# Altium schematic sheet AD9854优化版.SchDoc
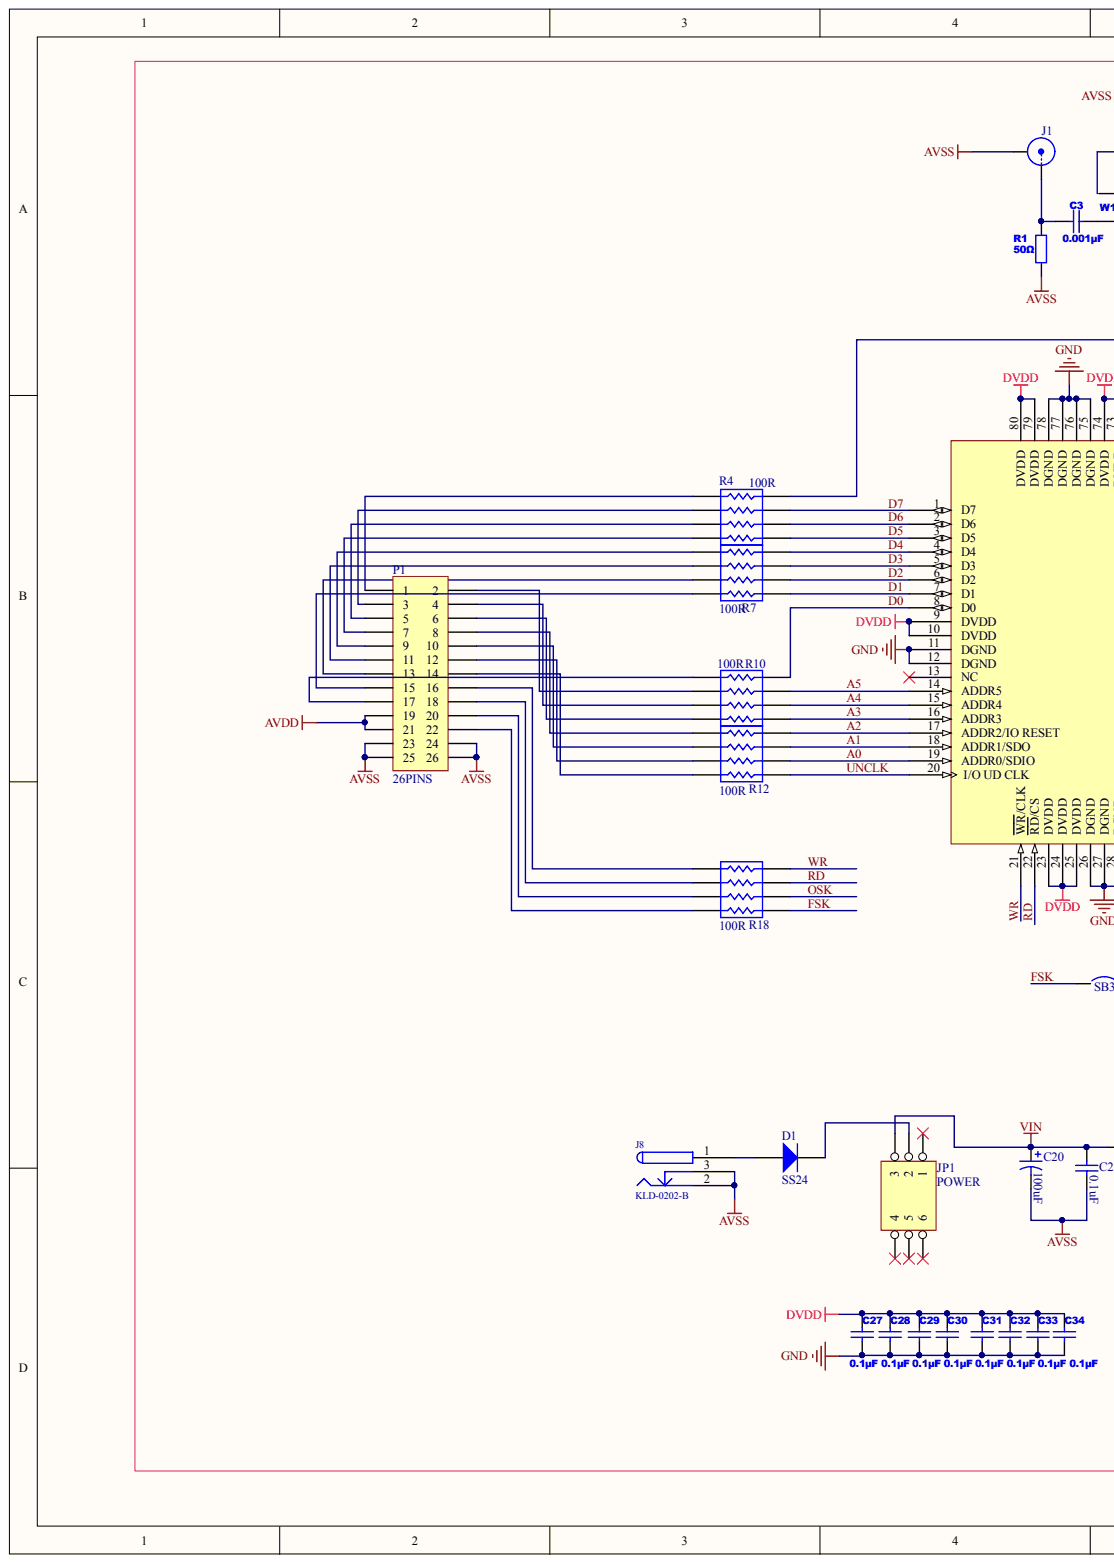
[diagram p0: AD9854优化版.SchDoc, left]
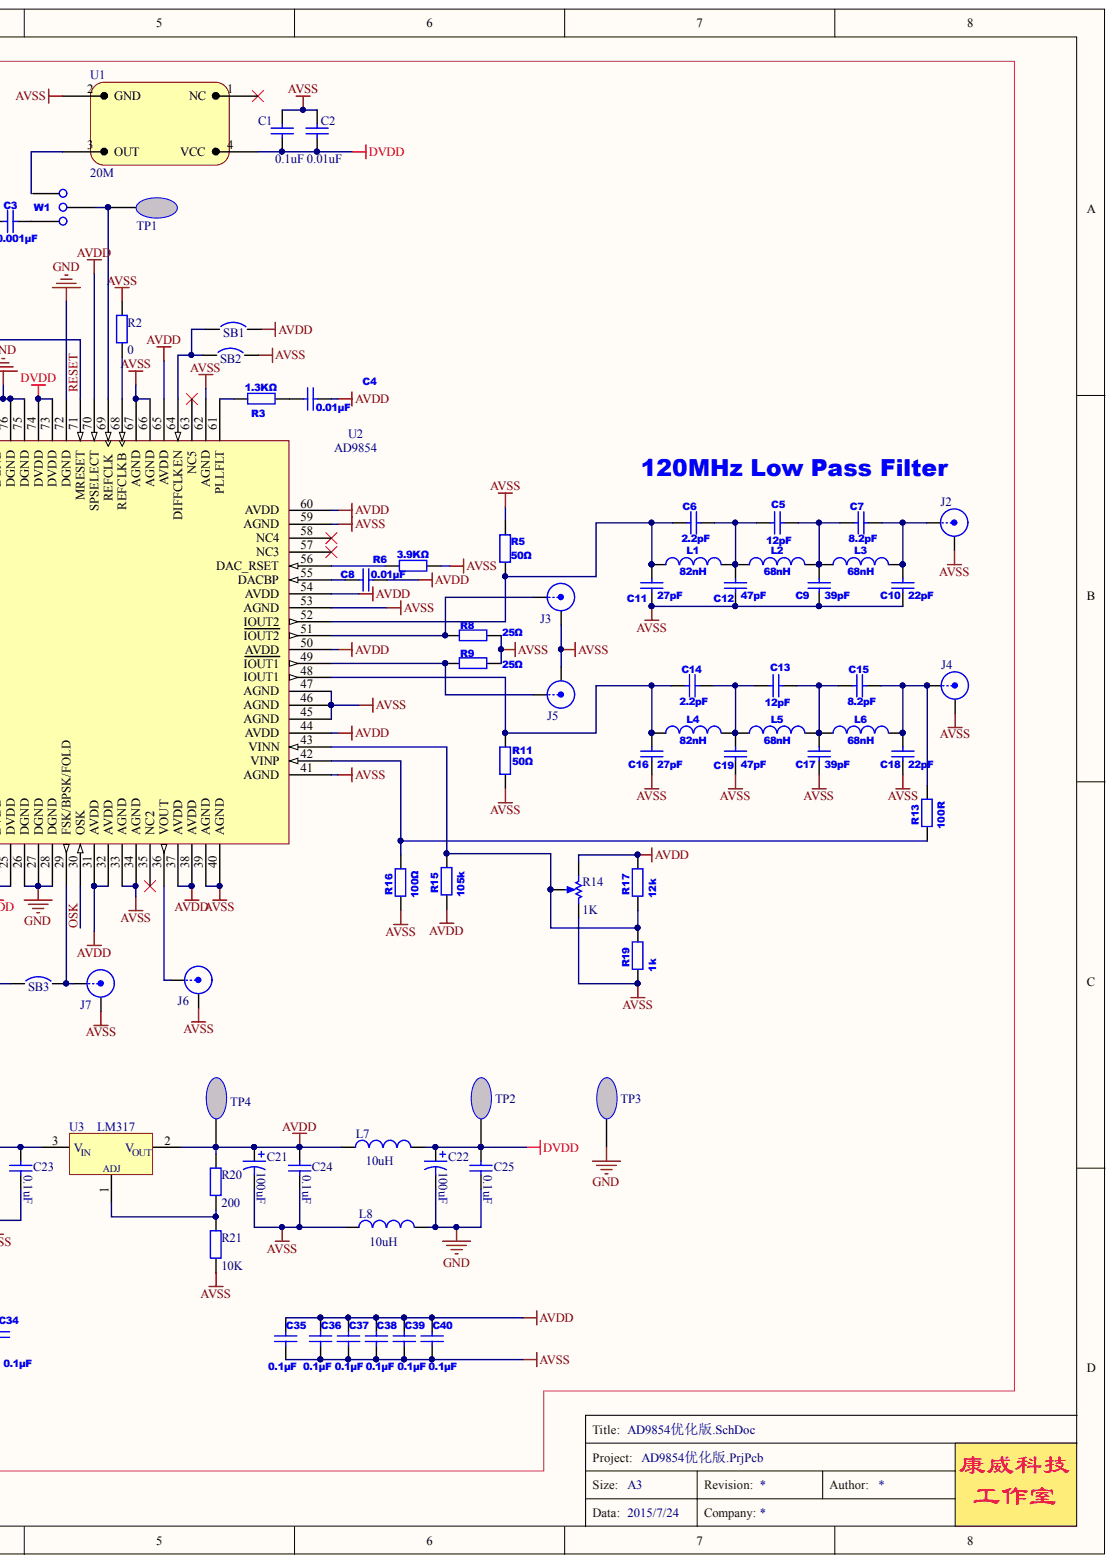
[diagram p1: AD9854优化版.SchDoc, right]

## Parts
C1  value 0.1uF  [0805-cap]
C2  value 0.01uF  [0805-cap]
C3  0.001μF  [0805-cap]
C4  0.01μF  [0805-cap]
C5  12pF  [0805-cap]
C6  2.2pF  [0805-cap]
C7  8.2pF  [0805-cap]
C8  0.01μF  [0805-cap]
C9  39pF  [C0805]
C10  22pF  [0805-cap]
C11  27pF  [C0805]
C12  47pF  [C0805]
C13  12pF  [0805-cap]
C14  2.2pF  [0805-cap]
C15  8.2pF  [0805-cap]
C16  27pF  [C0805]
C17  39pF  [C0805]
C18  22pF  [0805-cap]
C19  47pF  [C0805]
C20  value 100uF  [C7343]
C21  value 100uF  [C7343]
C22  value 100uF  [C7343]
C23  value 0.1uF  [C0805]
C24  value 0.1uF  [C0805]
C25  value 0.1uF  [C0805]
C27  0.1μF  [0805-cap]
C28  0.1μF  [0805-cap]
C29  0.1μF  [0805-cap]
C30  0.1μF  [0805-cap]
C31  0.1μF  [0805-cap]
C32  0.1μF  [0805-cap]
C33  0.1μF  [0805-cap]
C34  0.1μF  [0805-cap]
C35  0.1μF  [0805-cap]
C36  0.1μF  [0805-cap]
C37  0.1μF  [0805-cap]
C38  0.1μF  [0805-cap]
C39  0.1μF  [0805-cap]
C40  0.1μF  [0805-cap]
D1  SS24  [SMA]
J1  SMB  [SMA-H]  (value not drawn)
J2  SMB  [SM]  (value not drawn)
J3  SMB  [SM]  (value not drawn)
J4  SMB  [SM]  (value not drawn)
J5  SMB  [SM]  (value not drawn)
J6  SMB  [SMA-H]  (value not drawn)
J7  SMB  [SMA-H]  (value not drawn)
J8  KLD-0202-B  [DC-6mm]
JP1  POWER  [6脚开关]
L1  82nH  [L0805]
L2  68nH  [L0805]
L3  68nH  [L0805]
L4  82nH  [L0805]
L5  68nH  [L0805]
L6  68nH  [L0805]
L7  value 10uH  [L1812]
L8  value 10uH  [L1812]
P1  26PINS  [HDR2X13]
R1  50Ω  [R0805]
R2  value 0  [0805_r]
R3  1.3KΩ  [0805_r]
R4  100R  [RA4]
R5  50Ω  [R0805]
R6  3.9KΩ  [0805_r]
R7  100R  [RA4]
R8  25Ω  [0805_r]
R9  25Ω  [0805_r]
R10  100R  [RA4]
R11  50Ω  [R0805]
R12  100R  [RA4]
R13  100R  [R0805]
R14  value 1K  [3296W]
R15  105k  value 100Ω  [R0805]
R16  100Ω  [R0805]
R17  12k  [0805_r]
R18  100R  [RA4]
R19  1k  [0805_r]
R20  value 200  [0805_r]
R21  value 10K  [0805_r]
SB1  Circuit Breaker  [CONECT]  (value not drawn)
SB2  Circuit Breaker  [CONECT]  (value not drawn)
SB3  Circuit Breaker  [CONECT]  (value not drawn)
TP1  TEST  [TEST]  (value not drawn)
TP2  TEST  [TEST]  (value not drawn)
TP3  TEST  [TEST]  (value not drawn)
TP4  TEST  [TEST]  (value not drawn)
U1  20M  [power_JINZHEN]
U2  AD9854  [AD9854]
U3  LM317  [TO263]
W1  key  [HDR1X3]  (value not drawn)

## Nets
AVDD: C4.2 C8.2 C21.1 C24.2 C35.2 C36.2 C37.2 C38.2 C39.2 C40.2 L7.1 P1.19 P1.21 R14.2 R15.1 R17.2 R20.2 SB1.2 TP4.1 U2.31(AVDD) U2.32(AVDD) U2.37(AVDD) U2.38(AVDD) U2.44(AVDD) U2.50(AVDD) U2.54(AVDD) U2.60(AVDD) U2.65(AVDD) U2.70(SPSELECT) U3.2
AVSS: C1.1 C2.1 C9.1 C10.1 C11.1 C12.1 C16.1 C17.1 C18.1 C19.1 C20.2 C21.2 C23.1 C24.1 C35.1 C36.1 C37.1 C38.1 C39.1 C40.1 J1.2 J2.2 J3.2 J4.2 J5.2 J6.2 J7.2 J8.2 J8.3 L8.1 P1.23 P1.24 P1.25 P1.26 R1.1 R2.2 R5.1 R6.2 R8.2 R9.2 R11.1 R14.1 R16.1 R19.1 R21.2 SB2.2 U1.2(GND) U2.33(AGND) U2.34(AGND) U2.39(AGND) U2.40(AGND) U2.41(AGND) U2.45(AGND) U2.46(AGND) U2.47(AGND) U2.53(AGND) U2.59(AGND) U2.62(AGND) U2.66(AGND) U2.67(AGND)
DVDD: C1.2 C2.2 C22.1 C25.2 C27.2 C28.2 C29.2 C30.2 C31.2 C32.2 C33.2 C34.2 L7.2 TP2.1 U1.4(VCC) U2.9(DVDD) U2.10(DVDD) U2.23(DVDD) U2.24(DVDD) U2.25(DVDD) U2.73(DVDD) U2.74(DVDD) U2.79(DVDD) U2.80(DVDD)
GND: C22.2 C25.1 C27.1 C28.1 C29.1 C30.1 C31.1 C32.1 C33.1 C34.1 L8.2 TP3.1 U2.11(DGND) U2.12(DGND) U2.26(DGND) U2.27(DGND) U2.28(DGND) U2.72(DGND) U2.75(DGND) U2.76(DGND) U2.77(DGND) U2.78(DGND)
VIN: C20.1 C23.2 JP1.3 U3.3
A0: R12.3 U2.19(ADDR0/SDIO)
A1: R12.5 U2.18(ADDR1/SDO)
A2: R12.7 U2.17(ADDR2/IO_RESET)
A3: R10.1 U2.16(ADDR3)
A4: R10.3 U2.15(ADDR4)
A5: R10.5 U2.14(ADDR5)
D0: R10.7 U2.8(D0)
D1: R7.1 U2.7(D1)
D2: R7.3 U2.6(D2)
D3: R7.5 U2.5(D3)
D4: R7.7 U2.4(D4)
D5: R4.1 U2.3(D5)
D6: R4.3 U2.2(D6)
D7: R4.5 U2.1(D7)
FSK: R18.1 SB3.1
OSK: R18.3 U2.30(OSK)
RD: R18.5 U2.22(RD/CS)
RESET: R4.7 U2.71(MRESET)
UNCLK: R12.1 U2.20(I/O_UD_CLK)
WR: R18.7 U2.21(WR/CLK)
NetC3_1: C3.1 J1.1 R1.2
NetC3_2: C3.2 W1.3
NetC4_1: C4.1 R3.2
NetC5_1: C5.1 C6.2 C12.2 L1.2 L2.1
NetC5_2: C5.2 C7.1 C9.2 L2.2 L3.1
NetC6_1: C6.1 C11.2 L1.1 R5.2 U2.52(IOUT2)
NetC7_2: C7.2 C10.2 J2.1 L3.2
NetC8_1: C8.1 U2.55(DACBP)
NetC13_1: C13.1 C14.2 C19.2 L4.2 L5.1
NetC13_2: C13.2 C15.1 C17.2 L5.2 L6.1
NetC14_1: C14.1 C16.2 L4.1 R11.2 U2.48(IOUT1)
NetC15_2: C15.2 C18.2 J4.1 L6.2 R13.2
NetD1_1: D1.1 J8.1
NetD1_2: D1.2 JP1.2
NetJ3_1: J3.1 R8.1 U2.51(IOUT2)
NetJ5_1: J5.1 R9.1 U2.49(IOUT1)
NetJ6_1: J6.1 U2.36(VOUT)
NetJ7_1: J7.1 SB3.2 U2.29(FSK/BPSK/FOLD)
NetP1_1: P1.1 R4.8
NetP1_2: P1.2 R10.6
NetP1_3: P1.3 R4.6
NetP1_4: P1.4 R10.4
NetP1_5: P1.5 R4.4
NetP1_6: P1.6 R10.2
NetP1_7: P1.7 R4.2
NetP1_8: P1.8 R12.8
NetP1_9: P1.9 R7.8
NetP1_10: P1.10 R12.6
NetP1_11: P1.11 R7.6
NetP1_12: P1.12 R12.4
NetP1_13: P1.13 R7.4
NetP1_14: P1.14 R12.2
NetP1_15: P1.15 R7.2
NetP1_16: P1.16 R18.8
NetP1_17: P1.17 R10.8
NetP1_18: P1.18 R18.6
NetP1_20: P1.20 R18.4
NetP1_22: P1.22 R18.2
NetR2_1: R2.1 U2.68(REFCLKB)
NetR3_1: R3.1 U2.61(PLLFLT)
NetR6_1: R6.1 U2.56(DAC_RSET)
NetR13_1: R13.1 R16.2 U2.42(VINP)
NetR14_3: R14.3 R15.2 R17.1 R19.2 U2.43(VINN)
NetR20_1: R20.1 R21.1 U3.1
NetSB1_1: SB1.1 SB2.1 U2.64(DIFFCLKEN)
NetTP1_1: TP1.1 U2.69(REFCLK) W1.2
NetU1_3: U1.3(OUT) W1.1

Not connected: JP1 1 4 5 6; U1 1(NC); U2 13(NC) 35(NC2) 57(NC3) 58(NC4) 63(NC5)

## Library data of the parts
C1 C2 C5-C7 C9-C19 C23-C25 C27-C40 = Cap: Capacitor; Component Kind: Standard; LatestRevisionDate: 17-Jul-2002; LatestRevisionNote: Re-released for DXP Platform.; Library Name: Miscellaneous Devices.IntLib; PackageReference: RAD-0.3; Published: 8-Jun-2000; Signal Integrity: Cap; Simulation: CAP; Publisher: Altium Limited
  C1 C2 C23-C25: PackageDescription: Radial Cap, Thru-Hole; 2 Leads; 0.3 in Pin Spacing
  C5 C13: Value: 12pF
  C6 C14: Value: 2.2pF
  C7 C15: Value: 8.2pF
  C9 C17: Value: 39pF
  C10 C18: Value: 22pF
  C11 C16: Value: 27pF
  C12 C19: Value: 47pF
  C27-C40: Value: 0.1μF
C3 C4 C8 = Cap: Capacitor; Value: 0.01uF; Component Kind: Standard; LatestRevisionDate: 17-Jul-2002; LatestRevisionNote: Re-released for DXP Platform.; Library Name: Miscellaneous Devices.IntLib; PackageReference: RAD-0.3; Published: 8-Jun-2000; Signal Integrity: Cap; Simulation: CAP; Publisher: Altium Limited
C20-C22 = Cap Pol1: Polarized Capacitor (Radial); Component Kind: Standard; LatestRevisionDate: 17-Jul-2002; LatestRevisionNote: Re-released for DXP Platform.; Library Name: Miscellaneous Devices.IntLib; PackageDescription: Polarized Capacitor (Radial); 2 Leads; PackageReference: RB7.6-15; Published: 8-Jun-2000; Signal Integrity: Cap Pol; Simulation: CAP; Publisher: Altium Limited
D1 = DIODE: High Conductance Fast Diode; Code_JEDEC: DO-204-AH; Component Kind: Standard; ComponentLink1Description: Manufacturer Link; ComponentLink1URL: http://www.fairchildsemi.com/; ComponentLink2Description: Datasheet; ComponentLink2URL: http://www.fairchildsemi.com/ds/1N%2F1N4448.pdf; DatasheetVersion: 1997; LatestRevisionDate: 17-Jul-2002; LatestRevisionNote: Re-released for DXP Platform.; Library Name: Miscellaneous Devices.SchLib; PackageDescription: Diode, Axial; Body 3.8 x 1.9 mm (LxDia. max), Lead Dia. 0.53 mm (max); PackageReference: DO-35; Published: 8-Jun-2000; Publisher: Altium Limited
J1-J7 = SMB: SMB Straight Connector; Component Kind: Standard; LatestRevisionDate: 17-Jul-2002; LatestRevisionNote: Re-released for DXP Platform.; Library Name: Miscellaneous Connectors.IntLib; Signal Integrity: Connector; Publisher: Altium Limited
J8 = KLD-0202-B: Low Voltage Power Supply Connector; Manufacturer: Kycon; AEMS Code: 3510211; Component Kind: Standard; Library Name: My3D.SCHLIB; PCB3D: power_jack
JP1 = HEADER 3X2: Component Kind: Standard
L1 L4 L7 L8 = Inductor: Code_IEC: 1005; Code_JEITA: 0402; Component Kind: Standard; Description: Inductor; Library Name: Miscellaneous Devices.IntLib; PackageReference: 0402-A; Published: 8-Jun-2000; Signal Integrity: Inductor; Simulation: INDUCTOR; Publisher: Altium Limited
  L1 L4: LatestRevisionDate: 17-Jul-2002; LatestRevisionNote: Re-released for DXP Platform.; Value: 82nH
  L7 L8: LatestRevisionDate: 29-May-2009; LatestRevisionNote: IPC-7351 Footprint Added.; PackageDescription: Chip Inductor
L2 L3 L5 L6 = Inductor: Code_IEC: 1005; Value: 82nH; Code_JEITA: 0402; Component Kind: Standard; Description: Inductor; LatestRevisionDate: 17-Jul-2002; LatestRevisionNote: Re-released for DXP Platform.; Library Name: Miscellaneous Devices.IntLib; PackageReference: 0402-A; Published: 8-Jun-2000; Signal Integrity: Inductor; Simulation: INDUCTOR; Publisher: Altium Limited
P1 = Header 13X2H: Header, 13-Pin, Dual row, Right Angle; Component Kind: Standard; LatestRevisionDate: 17-Jul-2002; LatestRevisionNote: Re-released for DXP Platform.; Library Name: Miscellaneous Connectors.IntLib; Signal Integrity: Connector; Publisher: Altium Limited
R1-R3 R5 R6 R8 R9 R11 R15 R16 R20 R21 = Res2: Resistor; Component Kind: Standard; LatestRevisionDate: 17-Jul-2002; LatestRevisionNote: Re-released for DXP Platform.; Library Name: Miscellaneous Devices.IntLib; PackageReference: AXIAL-0.4; Published: 8-Jun-2000; Signal Integrity: Res; Simulation: RESISTOR; Publisher: Altium Limited
  R1 R5 R11: Value: 50Ω
  R2 R20 R21: PackageDescription: Axial Device, Thru-Hole; 2 Leads; 0.4 in Pin Spacing
  R3: Value: 1.3KΩ
  R6: Value: 3.9KΩ
  R8 R9: Value: 25Ω
  R16: Value: 100Ω
R4 R7 R10 R12 R18 = RESPACK1: Component Kind: Standard; Library Name: AD9854.SCHLIB
R13 R17 R19 = Res2: Resistor; Value: 100Ω; Component Kind: Standard; LatestRevisionDate: 17-Jul-2002; LatestRevisionNote: Re-released for DXP Platform.; Library Name: Miscellaneous Devices.IntLib; PackageReference: AXIAL-0.4; Published: 8-Jun-2000; Signal Integrity: Res; Simulation: RESISTOR; Publisher: Altium Limited
R14 = RPot: Potentiometer; Set Position: 0.5; Sim Note: Enter the Resistance value and the set point into parameter fields.; Component Kind: Standard; LatestRevisionDate: 17-Jul-2002; LatestRevisionNote: Re-released for DXP Platform.; Library Name: Miscellaneous Devices.IntLib; PackageDescription: Variable Resistor, Thru-Hole; 3 Leads; PackageReference: VR5; Published: 8-Jun-2000; Simulation: POT; Publisher: Altium Limited
SB1-SB3 = Circuit Breaker: Solder: NO; Component Kind: Standard; Description: Circuit Breaker; Library Name: MCD_TOOLS.SCHLIB; Published: 24-Mar-1999; Revision: July-2002: Re-released for DXP Platform.; Publisher: Altium Limited
TP1-TP4 = 测试口: Component Kind: Standard; Library Name: Xiaowei.SchLib
U1 = CRYS2: Component Kind: Standard
U3 = LM317: 3-Terminal Adjustable Positive Voltage Regulator; Component Kind: Standard; Library Name: My IC.SchLib; Simulation: LM317
W1 = W: KEY 1 to 2; Component Kind: Standard
U2 = AD9854: Component Kind: Standard; Library Name: Xiaowei.SchLib
